# Altium schematic sheet SIM808.SchDoc(SIM808)
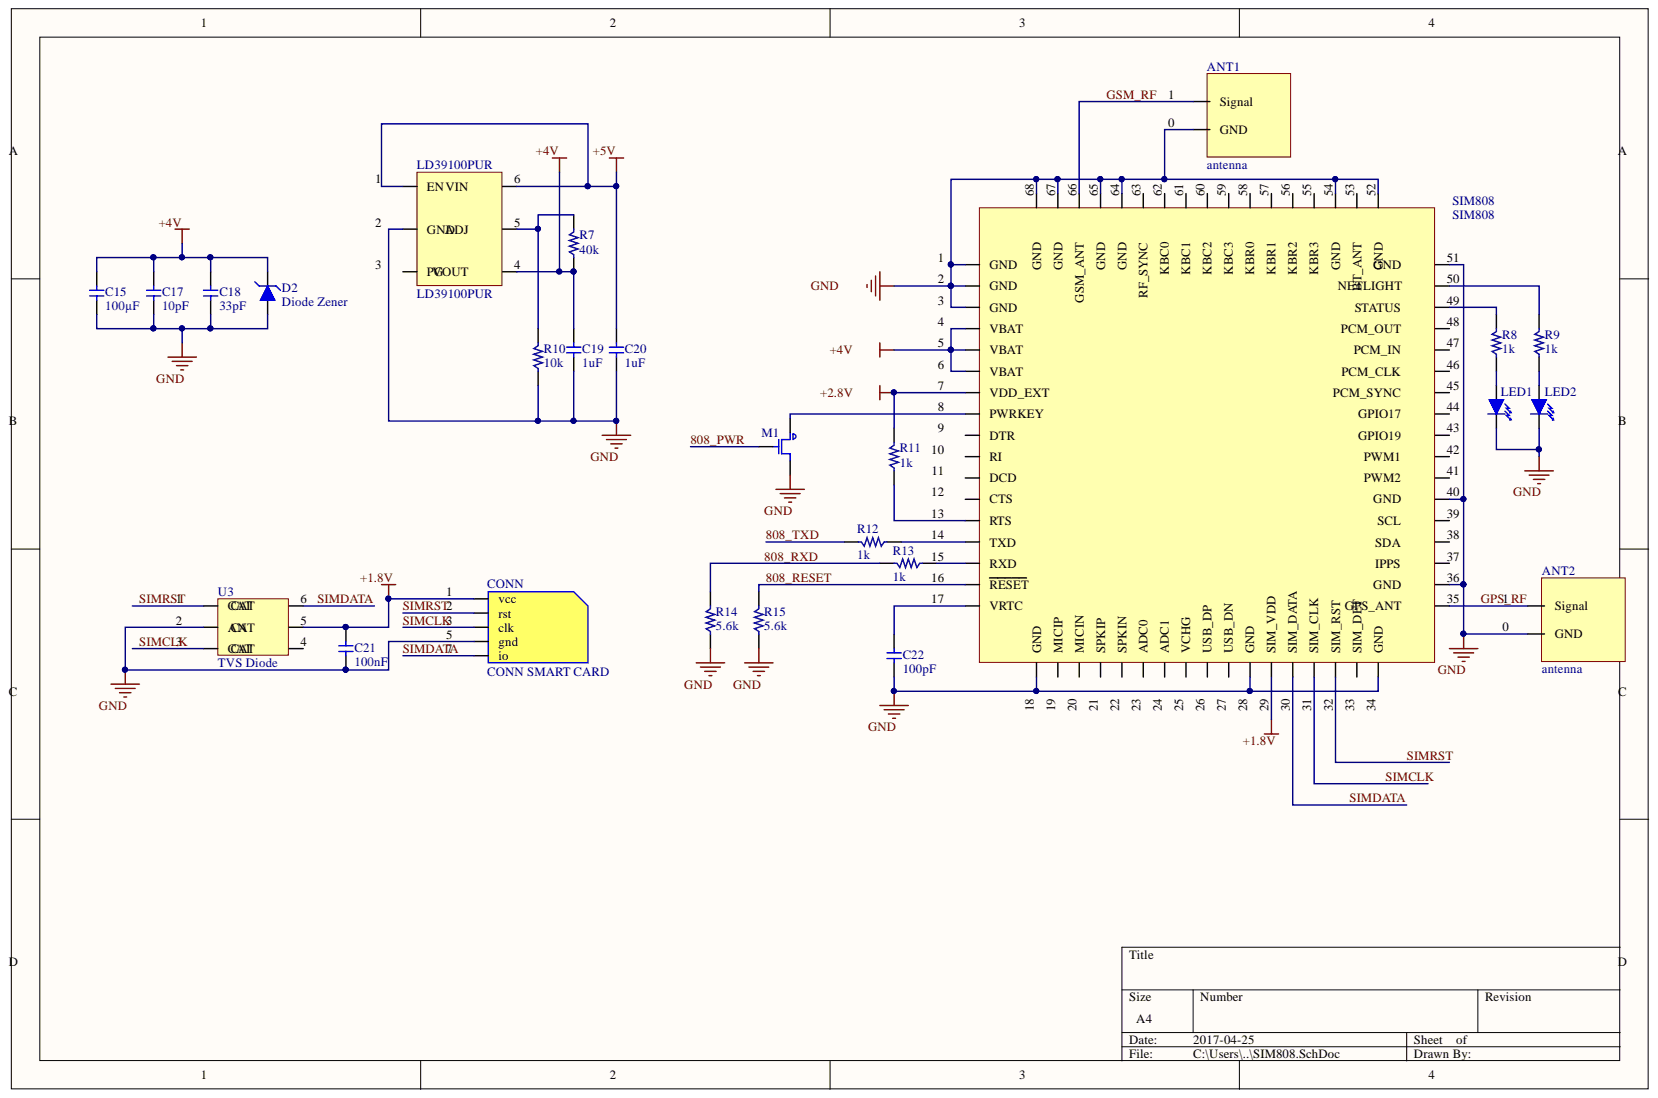
[diagram p0: SIM808.SchDoc]

## Parts
ANT1  antenna  [uFL]
ANT2  antenna  [uFL]
C15  Capacitance: 100µF  [Cap 1210]  (hidden comment: Cap Cer 100uF)
C17  value 10pF  [0805]  (hidden comment: Generic Capacitor)
C18  value 33pF  [0805]  (hidden comment: Generic Capacitor)
C19  value 1uF  [0805]  (hidden comment: Generic Capacitor)
C20  value 1uF  [0805]  (hidden comment: Generic Capacitor)
C21  value 100nF  [0805]  (hidden comment: Generic Capacitor)
C22  value 100pF  [0805]  (hidden comment: Generic Capacitor)
CONN  CONN SMART CARD  [CONN SMART CARD]
D2  Diode Zener  [Diode Zener]
LD39100PUR  LD39100PUR  [LD39100PUR]
LED1  Led Red  [0805 LED]  (value not drawn)
LED2  Led Green  [0805 LED]  (value not drawn)
M1  N-CH Mosfet Switch  [SOT32-3]  (value not drawn)
R7  value 40k  [0805]  (hidden comment: Resistor)
R8  value 1k  [0805]  (hidden comment: Resistor)
R9  value 1k  [0805]  (hidden comment: Resistor)
R10  value 10k  [0805]  (hidden comment: Resistor)
R11  value 1k  [0805]  (hidden comment: Resistor)
R12  value 1k  [0805]  (hidden comment: Resistor)
R13  value 1k  [0805]  (hidden comment: Resistor)
R14  value 5.6k  [0805]  (hidden comment: Resistor)
R15  value 5.6k  [0805]  (hidden comment: Resistor)
SIM808  SIM808  [SIM808]
U3  TVS Diode  [SMF05CT2G]

## Nets
+1.8V: C21.1 CONN.1(vcc) SIM808.29(SIM_VDD) U3.5
+2.8V: R11.2 SIM808.7(VDD_EXT)
+4V: C15.1 C17.1 C18.1 C19.2 D2.1 LD39100PUR.4 R7.1 SIM808.4(VBAT) SIM808.5(VBAT) SIM808.6(VBAT)
+5V: C20.1 LD39100PUR.1(EN_VIN) LD39100PUR.6
GND: ANT1.0 ANT2.0 C15.2 C17.2 C18.2 C19.1 C20.2 C21.2 C22.2 CONN.5(gnd) D2.2 LD39100PUR.2(GND) LED1.1 LED2.1 M1.2 R10.1 R14.1 R15.1 SIM808.1(GND) SIM808.2(GND) SIM808.3(GND) SIM808.18(GND) SIM808.28(GND) SIM808.34(GND) SIM808.36 SIM808.40 SIM808.51 SIM808.52 SIM808.54 SIM808.64 SIM808.65 SIM808.67 SIM808.68 U3.2(AN)
808_PWR: M1.1
808_RESET: R15.2 SIM808.16(RESET)
808_RXD: R13.2 R14.2
808_TXD: R12.2
GPS_RF: ANT2.1 SIM808.35
GSM_RF: ANT1.1 SIM808.66
SIMCLK: CONN.3(clk) SIM808.31(SIM_CLK) U3.3(CAT)
SIMDATA: CONN.7(io) SIM808.30(SIM_DATA) U3.6
SIMRST: CONN.2(rst) SIM808.32(SIM_RST) U3.1(CAT)
NetC22_1: C22.1 SIM808.17(VRTC)
NetLD39100PUR_5: LD39100PUR.5 R7.2 R10.2
NetLED1_2: LED1.2 R8.1
NetLED2_2: LED2.2 R9.1
NetM1_3: M1.3 SIM808.8(PWRKEY)
NetR8_2: R8.2 SIM808.49
NetR9_2: R9.2 SIM808.50
NetR11_1: R11.1 SIM808.13(RTS)
NetR12_1: R12.1 SIM808.14(TXD)
NetR13_1: R13.1 SIM808.15(RXD)

Not connected: LD39100PUR 3(PG); SIM808 9(DTR) 10(RI) 11(DCD) 12(CTS) 19(MICIP) 20(MICIN) 21(SPKIP) 22(SPKIN) 23(ADC0) 24(ADC1) 25(VCHG) 26(USB_DP) 27(USB_DN) 33(SIM_DET) 37 38 39 41 42 43 44 45 46 47 48 53 55 56 57 58 59 60 61 62 63; U3 4

## Library data of the parts
ANT1 ANT2 = antenna: uFL SMT Antenna Connector; mfr: adafruit; Component Kind: Standard; Library Name: uFLAntenna.SchLib; supplier: https://www.adafruit.com/product/1661
C15 = LMK325ABJ107MM-P: CAP CER 100UF 10V X5R 1210; Manufacturer: Taiyo Yuden; Tolerance: ±20%; Applications: General Purpose; Component Kind: Standard; ComponentLink1Description: http://ds.yuden.co.jp/TYCOMPAS/ut/detail.do?productNo=LMK325ABJ107MM-P&fileName=LMK325ABJ107MM-P_SS&mode=specSheetDownload; ComponentLink1URL: http://ds.yuden.co.jp/TYCOMPAS/ut/detail.do?productNo=LMK325ABJ107MM-P&fileName=LMK325ABJ107MM-P_SS&mode=specSheetDownload; Features: -; Height - Seated (Max): -; Lead Spacing: -; Lead Style: -; Library Name: SIM808.SchLib; Manufacturer Part Number: LMK325ABJ107MM-P; Mounting Type: Surface Mount, MLCC; Operating Temperature: -55°C ~ 85°C; Package / Case: 1210 (3225 Metric); Packaging: Cut Tape (CT); Part Status: Active; Pricing 1: 1=1.55, 10=1.231, 25=1.1284, 50=1.0668, 100=0.9231, 250=0.82052, 500=0.69746 (CAD); Ratings: -; Size / Dimension: 0.126" L x 0.098" W (3.20mm x 2.50mm); Supplier 1: Digi-Key; Supplier Part Number 1: 587-4317-1-ND; Temperature Coefficient: X5R; Thickness (Max): 0.110" (2.80mm); Voltage - Rated: 10V
C17-C22 = Capacitor: Component Kind: Standard; Description: Generic capacitor; Library Name: PowerCircuit.SchLib
CONN = CONN SMART CARD: Manufacturer: Wurth Electronics Inc; Manufacturer Part Number: 693022010811; Card Type: Micro SIM; Connector Type: Connector and Ejector; Insertion, Removal Method: Hinged Lid; Number of Positions: 8; Component Kind: Standard; ComponentLink1Description: http://katalog.we-online.de/em/datasheet/693022010811.pdf; ComponentLink1URL: http://katalog.we-online.de/em/datasheet/693022010811.pdf; Contact Finish: Gold; Contact Finish Thickness: -; Description: CONN SMART CARD; Ejector Side: -; Features: Shielded; Height Above Board: 0.077" (1.95mm); Library Name: SIM808.SchLib; Mounting Feature: Normal, Standard - Top; Mounting Type: Surface Mount, Right Angle; Packaging: Cut Tape (CT); Part Status: Active; Pricing 1: 1=2.6, 10=2.384, 50=2.2076, 100=2.0317, 500=1.76614 (CAD); Supplier 1: Digi-Key; Supplier Part Number 1: 732-5957-1-ND
D2 = MMSZ5231BT1G: DIODE ZENER 5.1V 500MW SOD123; Manufacturer: ON Semiconductor; Current - Reverse Leakage @ Vr: 5µA @ 2V; Impedance (Max) (Zzt): 17 Ohm; Tolerance: ±5%; Voltage - Forward (Vf) (Max) @ If: 900mV @ 10mA; Component Kind: Standard; ComponentLink1Description: http://www.onsemi.com/pub_link/Collateral/MMSZ5221BT1-D.PDF; ComponentLink1URL: http://www.onsemi.com/pub_link/Collateral/MMSZ5221BT1-D.PDF; Library Name: SIM808.SchLib; Manufacturer Part Number: MMSZ5231BT1G; Mounting Type: Surface Mount; Operating Temperature: -55°C ~ 150°C; Package / Case: SOD-123; Packaging: Cut Tape (CT); Part Status: Active; Power - Max: 500mW; Pricing 1: 1=0.34, 10=0.282, 100=0.149, 500=0.09802, 1000=0.06666 (CAD); Supplier 1: Digi-Key; Supplier Device Package: SOD-123; Supplier Part Number 1: MMSZ5231BT1GOSCT-ND; Voltage - Zener (Nom) (Vz): 5.1V
LD39100PUR = LD39100PUR: Adjustable linear voltage regulator; mfr: ST; Component Kind: Standard; Library Name: SIM808.SchLib; supplier: http://www.digikey.ca/product-detail/en/stmicroelectronics/LD39100PUR/497-11013-1-ND/2524221
LED1 = LTST-C171EKT: LED RED CLEAR 0805 SMD; Manufacturer: Lite-On Inc.; Current - Test: 20mA; Lens Color: Colorless; Lens Style/Size: Rectangle with Flat Top, 1.40mm x 1.25mm; Millicandela Rating: 6mcd; Viewing Angle: 130°; Voltage - Forward (Vf) (Typ): 2V; Wavelength - Dominant: 621nm; Wavelength - Peak: 630nm; Color: Red; Component Kind: Standard; ComponentLink1Description: http://media.digikey.com/pdf/Data%20Sheets/Lite-On%20PDFs/LTST-C171EKT.pdf; ComponentLink1URL: http://media.digikey.com/pdf/Data%20Sheets/Lite-On%20PDFs/LTST-C171EKT.pdf; Features: -; Height (Max): 0.80mm; Lens Transparency: Clear; Library Name: SIM808.SchLib; Manufacturer Part Number: LTST-C171EKT; Mounting Type: Surface Mount; Package / Case: 0805 (2012 Metric); Packaging: Cut Tape (CT); Part Status: Active; Pricing 1: 1=0.48, 10=0.351, 25=0.2588, 100=0.1848, 250=0.12564, 500=0.11086, 1000=0.08684 (CAD); Size / Dimension: 2.00mm L x 1.25mm W; Supplier 1: Digi-Key; Supplier Device Package: Chip LED; Supplier Part Number 1: 160-1422-1-ND
LED2 = LTST-C170GKT: LED GREEN CLEAR 0805 SMD; Manufacturer: Lite-On Inc.; Current - Test: 10mA; Lens Color: Colorless; Lens Style/Size: Rectangle with Flat Top, 1.40mm x 1.25mm; Millicandela Rating: 6mcd; Viewing Angle: 130°; Voltage - Forward (Vf) (Typ): 2.1V; Wavelength - Dominant: 569nm; Wavelength - Peak: 565nm; Color: Green; Component Kind: Standard; ComponentLink1Description: http://media.digikey.com/pdf/Data%20Sheets/Lite-On%20PDFs/LTST-C170GKT.pdf; ComponentLink1URL: http://media.digikey.com/pdf/Data%20Sheets/Lite-On%20PDFs/LTST-C170GKT.pdf; Features: -; Height (Max): 1.10mm; Lens Transparency: Clear; Library Name: SIM808.SchLib; Manufacturer Part Number: LTST-C170GKT; Mounting Type: Surface Mount; Package / Case: 0805 (2012 Metric); Packaging: Cut Tape (CT); Part Status: Active; Pricing 1: 1=0.48, 10=0.351, 25=0.2588, 100=0.1848, 250=0.12564, 500=0.11086, 1000=0.08684 (CAD); Size / Dimension: 2.00mm L x 1.25mm W; Supplier 1: Digi-Key; Supplier Device Package: -; Supplier Part Number 1: 160-1179-1-ND
M1 = BSH105,215: MOSFET N-CH 20V 1.05A SOT23; Manufacturer: NXP USA Inc.; Current - Continuous Drain (Id) @ 25°C: 1.05A (Ta); Drive Voltage (Max Rds On,  Min Rds On): 1.8V, 4.5V; FET Type: N-Channel; Gate Charge (Qg) (Max) @ Vgs: 3.9nC @ 4.5V; Input Capacitance (Ciss) (Max) @ Vds: 152pF @ 16V; Power Dissipation (Max): 417mW (Ta); Rds On (Max) @ Id, Vgs: 200 mOhm @ 600mA, 4.5V; Vgs (Max): ±8V; Vgs(th) (Max) @ Id: 570mV @ 1mA; Component Kind: Standard; ComponentLink1Description: http://www.nxp.com/documents/data_sheet/BSH105.pdf; ComponentLink1URL: http://www.nxp.com/documents/data_sheet/BSH105.pdf; Drain to Source Voltage (Vdss): 20V; FET Feature: -; Library Name: SIM808.SchLib; Manufacturer Part Number: BSH105,215; Mounting Type: Surface Mount; Operating Temperature: -55°C ~ 150°C (TJ); Package / Case: TO-236-3, SC-59, SOT-23-3; Packaging: Cut Tape (CT); Part Status: Active; Pricing 1: 1=0.53, 10=0.425, 100=0.2902, 500=0.21758, 1000=0.16318 (CAD); Supplier 1: Digi-Key; Supplier Device Package: TO-236AB (SOT23); Supplier Part Number 1: 568-6215-1-ND; Technology: MOSFET (Metal Oxide)
R7-R15 = Resistor: Generic Resistor; Component Kind: Standard; Library Name: PowerCircuit.SchLib
SIM808 = SIM808: SIM808 GSM + GPRS + GPS Cellular Module; Mfr: SimCom; Component Kind: Standard; Library Name: SIM808.SchLib; Supplier: http://www.ebay.ca/itm/291879777454?_trksid=p2057872.m2749.l2649&ssPageName=STRK%3AMEBIDX%3AIT
U3 = SMF05CT2G: TVS DIODE 5VWM 12.5VC SOT363; Manufacturer: ON Semiconductor; Capacitance @ Frequency: 80pF @ 1MHz; Current - Peak Pulse (10/1000µs): 8A (8/20µs); Power - Peak Pulse: 100W; Power Line Protection: No; Unidirectional Channels: 5; Voltage - Breakdown (Min): 6.2V; Voltage - Clamping (Max) @ Ipp: 12.5V; Voltage - Reverse Standoff (Typ): 5V (Max); Applications: Automotive; Bidirectional Channels: -; Component Kind: Standard; ComponentLink1Description: http://www.onsemi.com/pub_link/Collateral/SMF05C-D.PDF; ComponentLink1URL: http://www.onsemi.com/pub_link/Collateral/SMF05C-D.PDF; Library Name: SIM808.SchLib; Manufacturer Part Number: SMF05CT2G; Mounting Type: Surface Mount; Operating Temperature: -40°C ~ 125°C (TJ); Package / Case: 6-TSSOP, SC-88, SOT-363; Packaging: Cut Tape (CT); Part Status: Active; Pricing 1: 1=0.53, 10=0.424, 100=0.2891, 500=0.21684, 1000=0.16262 (CAD); Supplier 1: Digi-Key; Supplier Device Package: SC-88/SC70-6/SOT-363; Supplier Part Number 1: SMF05CT2GOSCT-ND; Type: Zener
